# Altium schematic sheet ul_tx_ctrl.SchDoc
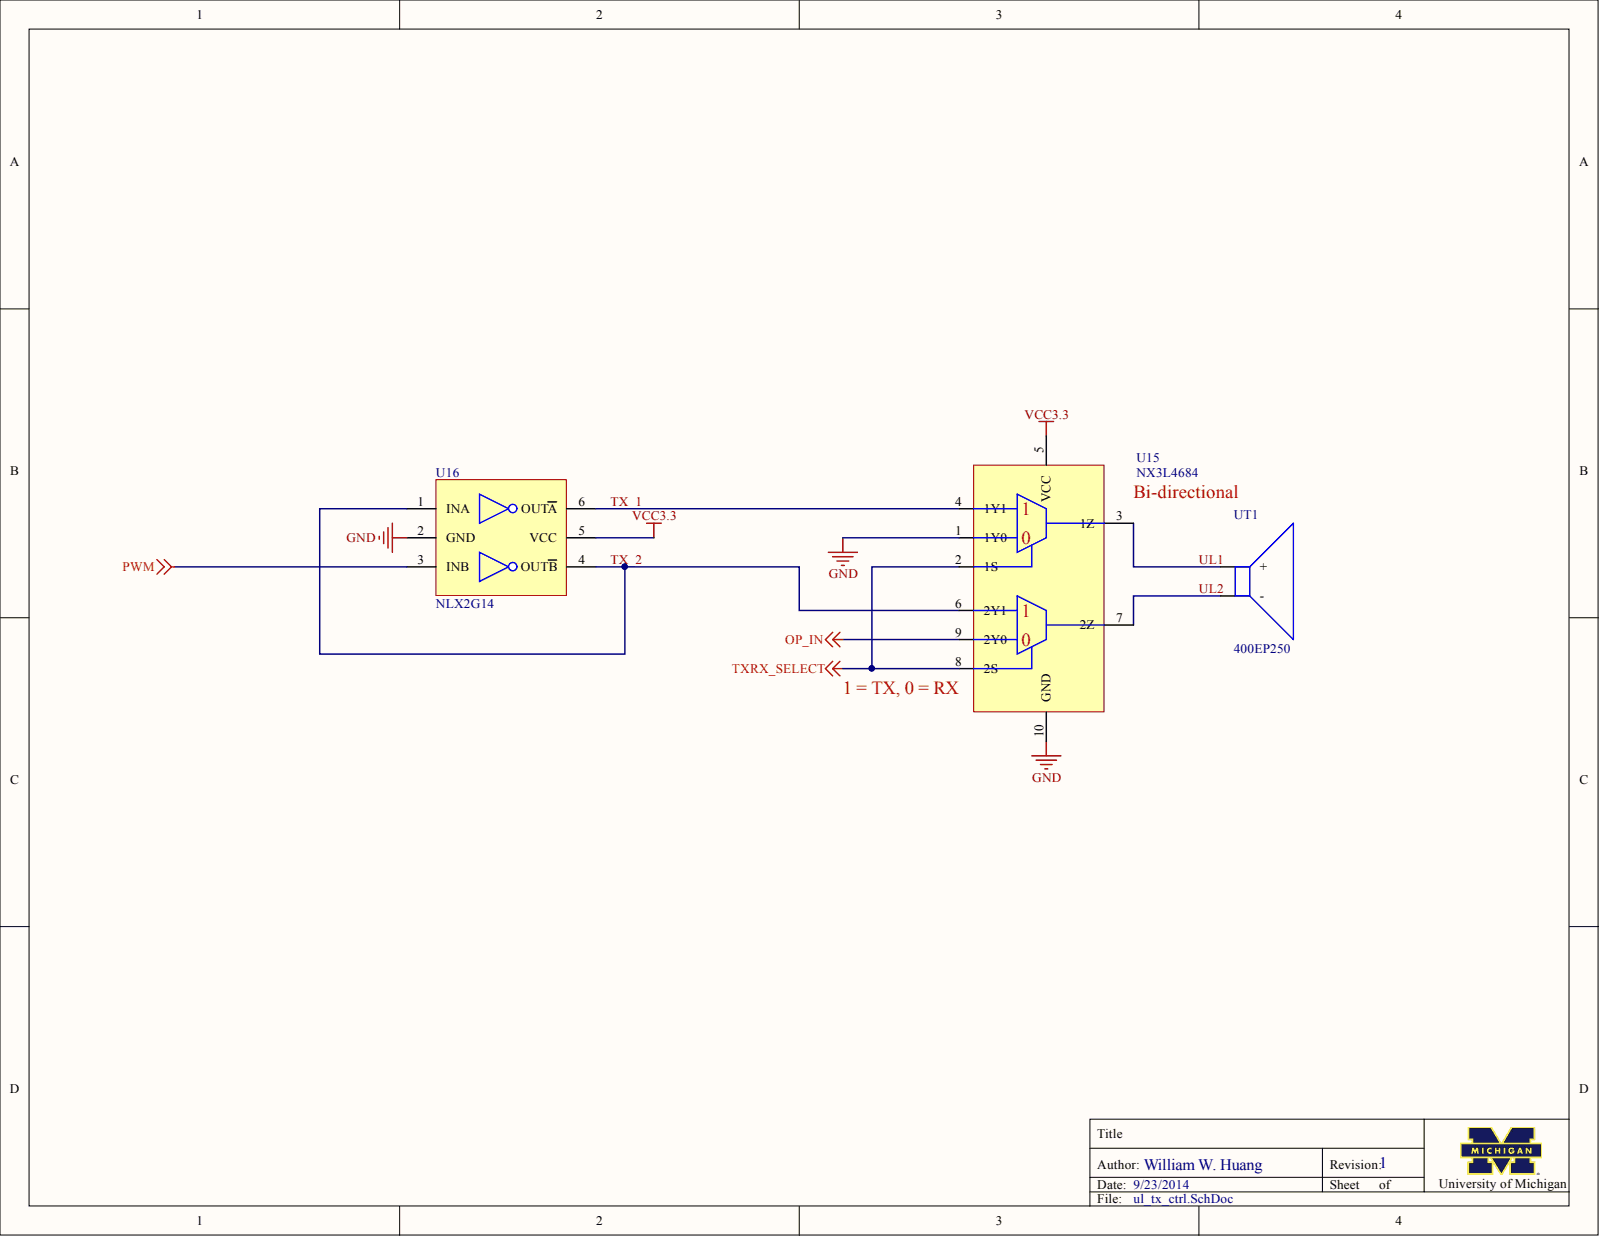
[diagram p0: ul_tx_ctrl.SchDoc]

## Parts
U15  NX3L4684  [QFN50P155X200X13-10N]
U16  NLX2G14  [ULLGA6]
UT1  400EP250  [Transducer recepticle]

## Nets
GND: U15.1(1Y0) U15.10(GND) U16.2(GND)
OP_IN: U15.9(2Y0)
PWM: U16.3(INB)
TXRX_SELECT: U15.2(1S) U15.8(2S)
VCC3.3: U15.5(VCC) U16.5(VCC)
TX_1: U15.4(1Y1) U16.6(OUTA)
TX_2: U15.6(2Y1) U16.1(INA) U16.4(OUTB)
UL1: U15.3(1Z) UT1.2
UL2: U15.7(2Z) UT1.1

## Library data of the parts
U15 = NX3L4684: IC ANALOG SWITCH SPDT 10XQFN; Component Kind: Standard; Supplier 1: Digi-Key; Supplier Part Number 1: 568-5564-1-ND; Library Name: opo.SchLib
U16 = NLX2G14: Dual Schmitt-Trigger  Inverter; Component Kind: Standard; Supplier 1: Digi-Key; Supplier Part Number 1: NLX2G14AMX1TCGOSCT-ND; Library Name: opo.SchLib
UT1 = Tranducer: Air Ultrasounic Ceramic Transducers; Component Kind: Standard; Supplier 1: Digi-Key; Supplier Part Number 1: ED90088-ND; Library Name: opo_general.SchLib
